# Altium schematic sheet EVB PRO.SchDoc("EVB PRO")
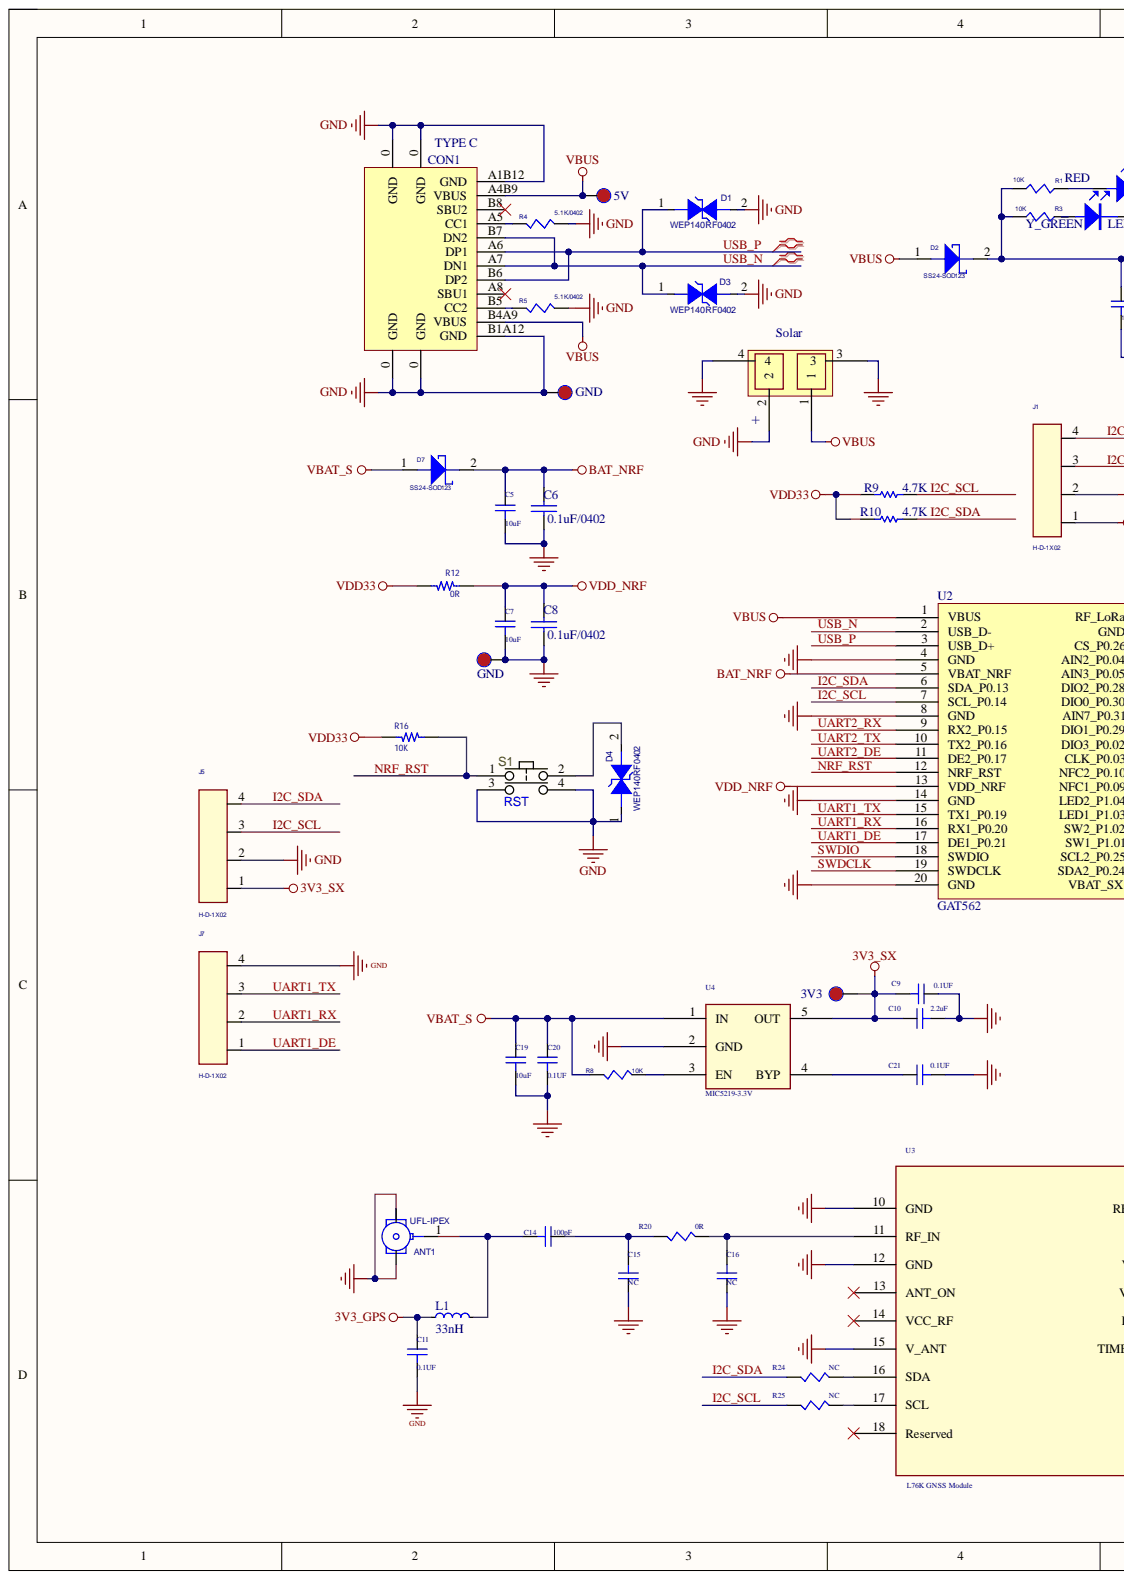
[diagram p0: EVB PRO.SchDoc, left]
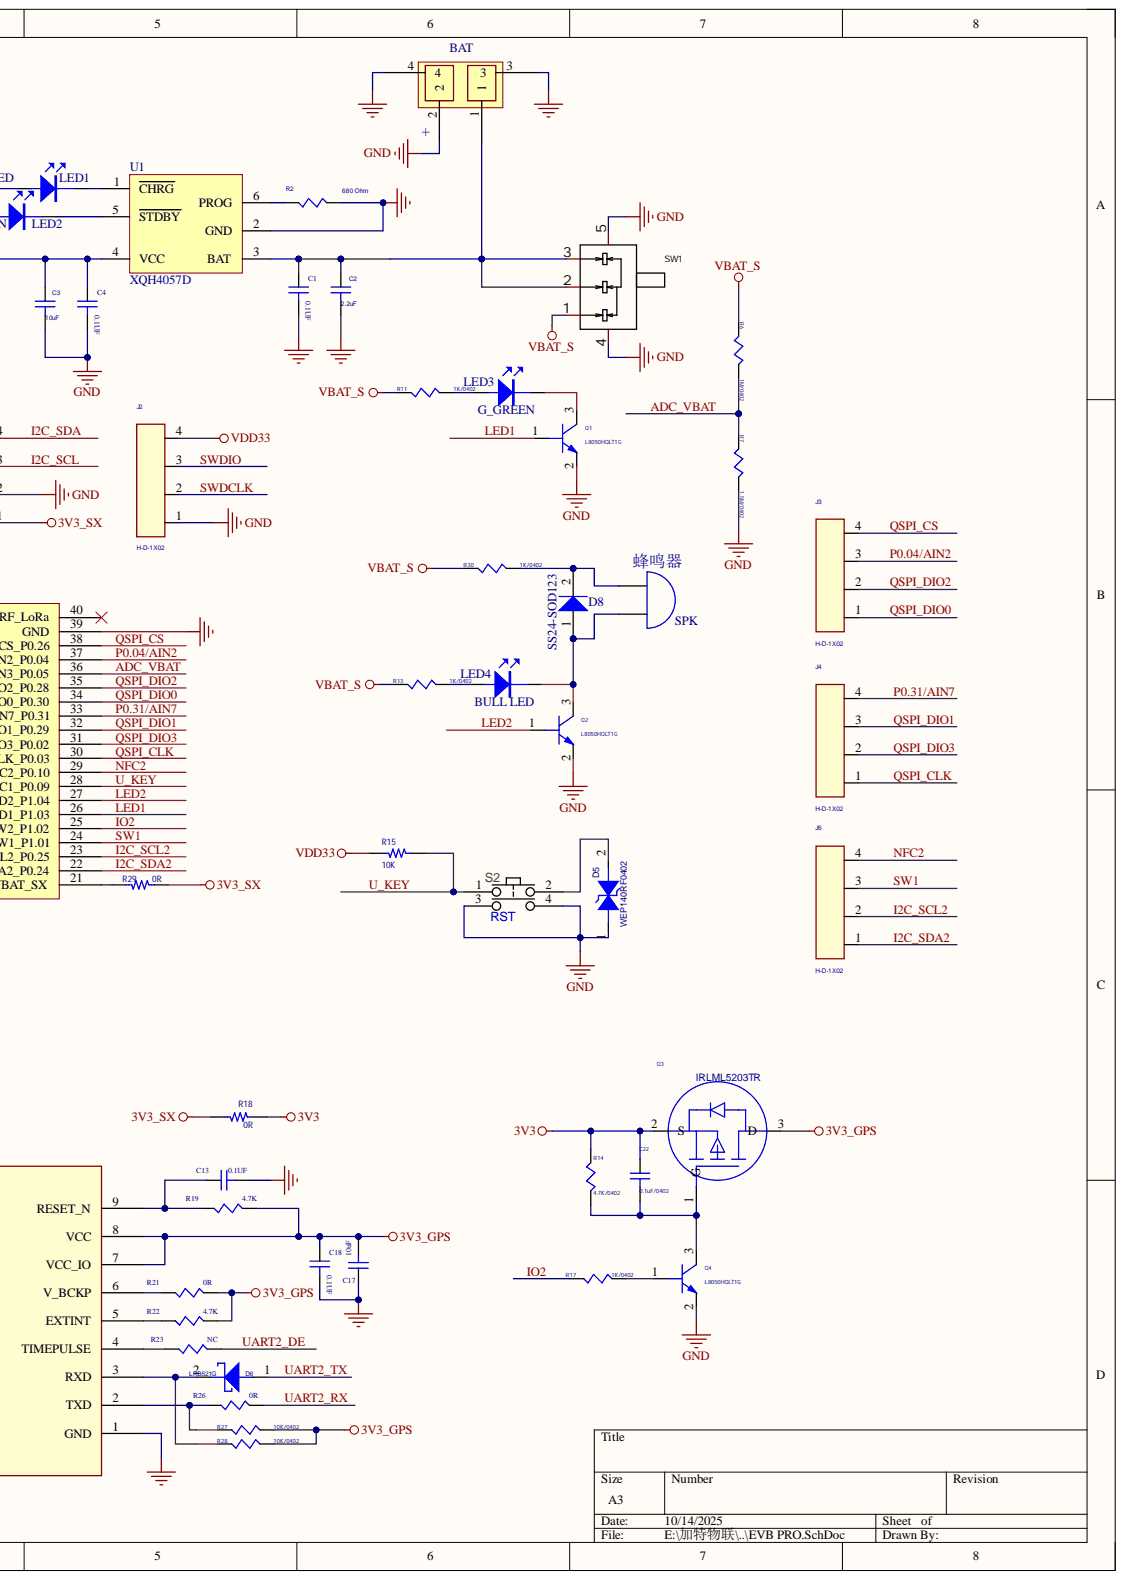
[diagram p1: EVB PRO.SchDoc, right]

## Parts
3V3  (no value)  [PAD_29mil]  (no BOM)
5V  (no value)  [PAD_29mil]  (no BOM)
ANT1  UFL-IPEX  [IPEX-0.7X2.2-1.35_3D]
BAT  H1  [125T-2P]  (value not drawn)
C1  0.1UF  [C0402]
C2  2.2uF  [C0402]
C3  10uF  [C0402]
C4  0.1UF  [C0402]
C5  10uF  [C0402]
C6  0.1uF/0402  [C0402]
C7  10uF  [C0402]
C8  0.1uF/0402  [C0402]
C9  0.1UF  [C0402]
C10  2.2uF  [C0402]
C11  0.1UF  [C0402]
C13  0.1UF  [C0402]
C14  100pF  [C0402]
C15  NC  [C0402]
C16  NC  [C0402]
C17  10uF  [C0402]
C18  0.1UF  [C0402]
C19  10uF  [C0402]
C20  0.1UF  [C0402]
C21  0.1UF  [C0402]
C22  0.1uF/0402  [C0402]
CON1  TYPE C  [TYPE C 12]
D1  WEP140RF0402  [ESD0402]
D2  SS24-SOD123  [SOD-123-1]
D3  WEP140RF0402  [ESD0402]
D4  WEP140RF0402  [ESD0402]
D5  WEP140RF0402  [ESD0402]
D6  LRB521G  [SOD723]
D7  SS24-SOD123  [SOD-123-1]
D8  SS24-SOD123  [SOD-123-1]
GND  (no value)  [PAD_29mil]  (no BOM)
GND  (no value)  [PAD_29mil]  (no BOM)
J1  H-D-1X02  [HDR1X4]
J2  H-D-1X02  [HDR1X4]
J3  H-D-1X02  [HDR1X4]
J4  H-D-1X02  [HDR1X4]
J5  H-D-1X02  [HDR1X4]
J6  H-D-1X02  [HDR1X4]
J7  H-D-1X02  [HDR1X4]
L1  33nH  [R0402]
LED1  RED  [LED0603_RED_3D]
LED2  Y_GREEN  [LED0603_RED_3D]
LED3  G_GREEN  [LED0603_RED_3D]
LED4  BULL LED  [LED0603_RED_3D]
Q1  L8050HQLT1G  [SOT23]
Q2  L8050HQLT1G  [SOT23]
Q3  IRLML5203TR  [SOT23]
Q4  L8050HQLT1G  [SOT23]
R1  10K  [R0402]
R2  680 Ohm  [R0402]
R3  10K  [R0402]
R4  5.1K/0402  [R0402]
R5  5.1K/0402  [R0402]
R6  1M/0402  [R0402]
R7  1.5M/0402  [R0402]
R8  10K  [R0402]
R9  4.7K  [X0402R_3D]
R10  4.7K  [X0402R_3D]
R11  1K/0402  [R0402]
R12  0R  [R0402]
R13  1K/0402  [R0402]
R14  4.7K/0402  [R0402]
R15  10K  [R0402]
R16  10K  [R0402]
R17  1K/0402  [R0402]
R18  0R  [R0402]
R19  4.7K  [R0402]
R20  0R  [R0402]
R21  0R  [R0402]
R22  4.7K  [R0402]
R23  NC  [R0402]
R24  NC  [R0402]
R25  NC  [R0402]
R26  0R  [R0402]
R27  10K/0402  [R0402]
R28  10K/0402  [R0402]
R29  0R  [R0402]
R30  1K/0402  [R0402]
S1  RST  [KEY_3*3*1.5]
S2  RST  [KEY_3*3*1.5]
Solar  H1  [125T-2P]  (value not drawn)
SPK  蜂鸣器  [HDR1X2]
SW1  SK12D07VG4  [SW-TH_MSK12C02]  (value not drawn)
U1  XQH4057D  [XQH4057D]
U2  GAT562  [GAT562]
U3  L76K GNSS Module  [MAX-7]
U4  MIC5219-3.3V  [SOT23-5]

## Nets
3V3: C22.1 Q3.2 R14.2 R18.2
3V3_GPS: C11.1 C17.2 C18.1 L1.2 Q3.3 R19.1 R21.1 R22.1 R27.1 R28.1 U3.7(VCC_IO) U3.8(VCC)
3V3_SX: 3V3.1 C9.2 C10.1 J1.1 J5.1 R18.1 R29.2 U4.5(OUT)
BAT_NRF: C5.1 C6.2 D7.2 U2.5(VBAT_NRF)
GND: ANT1.2 ANT1.3 BAT.2 BAT.3 BAT.4 C1.2 C2.2 C3.2 C4.2 C5.2 C6.1 C7.2 C8.1 C9.1 C10.2 C11.2 C13.2 C15.1 C16.1 C17.1 C18.2 C19.2 C20.2 C21.2 CON1.0(GND) CON1.A1B12(GND) CON1.B1A12(GND) D1.2 D3.2 D4.1 D5.1 GND.1 J1.2 J2.1 J5.2 J7.4 Q1.2 Q2.2 Q4.2 R2.2 R4.2 R5.2 R7.1 S1.3 S1.4 S2.3 S2.4 Solar.2 Solar.3 Solar.4 SW1.4 SW1.5 U1.2(GND) U2.4(GND) U2.8(GND) U2.14(GND) U2.20(GND) U2.39(GND) U3.1(GND) U3.10(GND) U3.12(GND) U3.15(V_ANT) U4.2(GND)
VBAT_S: C19.1 C20.1 D7.1 R6.2 R8.1 R11.2 R13.2 R30.2 SW1.1 U4.1(IN)
VBUS: 5V.1 CON1.A4B9(VBUS) CON1.B4A9(VBUS) D2.1 Solar.1 U2.1(VBUS)
VDD33: J2.4 R9.1 R10.2 R12.1 R15.1 R16.1
VDD_NRF: C7.1 C8.2 R12.2 U2.13(VDD_NRF)
ADC_VBAT: R6.1 R7.2 U2.36(AIN3_P0.05)
I2C_SCL: J1.3 J5.3 R9.2 R25.2 U2.7(SCL_P0.14)
I2C_SCL2: J6.2 U2.23(SCL2_P0.25)
I2C_SDA: J1.4 J5.4 R10.1 R24.2 U2.6(SDA_P0.13)
I2C_SDA2: J6.1 U2.22(SDA2_P0.24)
IO2: R17.2 U2.25(SW2_P1.02)
LED1: Q1.1 U2.26(LED1_P1.03)
LED2: Q2.1 U2.27(LED2_P1.04)
NFC2: J6.4 U2.29(NFC2_P0.10)
NRF_RST: R16.2 S1.1 U2.12(NRF_RST)
P0.04/AIN2: J3.3 U2.37(AIN2_P0.04)
P0.31/AIN7: J4.4 U2.33(AIN7_P0.31)
QSPI_CLK: J4.1 U2.30(CLK_P0.03)
QSPI_CS: J3.4 U2.38(CS_P0.26)
QSPI_DIO0: J3.1 U2.34(DIO0_P0.30)
QSPI_DIO1: J4.3 U2.32(DIO1_P0.29)
QSPI_DIO2: J3.2 U2.35(DIO2_P0.28)
QSPI_DIO3: J4.2 U2.31(DIO3_P0.02)
SW1: J6.3 U2.24(SW1_P1.01)
SWDCLK: J2.2 U2.19(SWDCLK)
SWDIO: J2.3 U2.18(SWDIO)
U_KEY: R15.2 S2.1 U2.28(NFC1_P0.09)
UART1_DE: J7.1 U2.17(DE1_P0.21)
UART1_RX: J7.2 U2.16(RX1_P0.20)
UART1_TX: J7.3 U2.15(TX1_P0.19)
UART2_DE: R23.1 U2.11(DE2_P0.17)
UART2_RX: R26.1 U2.9(RX2_P0.15)
UART2_TX: D6.1 U2.10(TX2_P0.16)
USB_N: CON1.A7(DN1) CON1.B7(DN2) D3.1 U2.2(USB_D-)
USB_P: CON1.A6(DP1) CON1.B6(DP2) D1.1 U2.3(USB_D+)
NetANT1_1: ANT1.1 C14.2 L1.1
NetBAT_1: BAT.1 C1.1 C2.1 SW1.2 SW1.3 U1.3(BAT)
NetC3_1: C3.1 C4.1 D2.2 R1.2 R3.2 U1.4(VCC)
NetC13_1: C13.1 R19.2 U3.9(RESET_N)
NetC14_1: C14.1 C15.2 R20.2
NetC16_2: C16.2 R20.1 U3.11(RF_IN)
NetC21_1: C21.1 U4.4(BYP)
NetC22_2: C22.2 Q3.1 Q4.3 R14.1
NetCON1_A5: CON1.A5(CC1) R4.1
NetCON1_B5: CON1.B5(CC2) R5.1
NetD4_2: D4.2 S1.2
NetD5_2: D5.2 S2.2
NetD6_2: D6.2 R28.2 U3.3(RXD)
NetD8_1: D8.1 LED4.2 Q2.3 SPK.2
NetD8_2: D8.2 R30.1 SPK.1
NetLED1_1: LED1.1 R1.1
NetLED1_2: LED1.2 U1.1(CHRG)
NetLED2_1: LED2.1 R3.1
NetLED2_2: LED2.2 U1.5(STDBY)
NetLED3_1: LED3.1 R11.1
NetLED3_2: LED3.2 Q1.3
NetLED4_1: LED4.1 R13.1
NetQ4_1: Q4.1 R17.1
NetR2_1: R2.1 U1.6(PROG)
NetR8_2: R8.2 U4.3(EN)
NetR21_2: R21.2 U3.6(V_BCKP)
NetR22_2: R22.2 U3.5(EXTINT)
NetR23_2: R23.2 U3.4(TIMEPULSE)
NetR24_1: R24.1 U3.16(SDA)
NetR25_1: R25.1 U3.17(SCL)
NetR26_2: R26.2 R27.2 U3.2(TXD)
NetR29_1: R29.1 U2.21(VBAT_SX)

Not connected: CON1 A8(SBU1) B8(SBU2); U2 40(RF_LoRa); U3 13(ANT_ON) 14(VCC_RF) 18(Reserved)

## Library data of the parts
3V3 5V GND GND = TEST-DOT: Library Name: EasyARM-i.MX283-EV.SCHLIB
ANT1 = UFL-IPEX_3PIN-S: Component Kind: Standard; Library Name: schlib.SCHLIB
BAT Solar = 2P BAT: Component Kind: Standard; Library Name: PCB_Project.SCHLIB
C1 C4 C5 C7 C9-C11 C13-C21 = 0_1.1UF: Component Kind: Standard
C2 C3 C22 = 0.1UF: Component Kind: Standard
C6 C8 = CAP: Capacitor; Component Kind: Standard; Library Name: 电阻容感类.SchLib
CON1 = TYPE C: MODE:U262-161N-4BVC11/C319148; Component Kind: Standard; Library Name: Schlib1.SchLib
D1 D3-D5 = DIODE SCHOTTKY_1: Component Kind: Standard
D2 D6 D7 = DIODE SCHOTTKY_0: DIODE 1N5817,DIP, 20V, 1A; Vendor: DIODES Incorporated.; Vendor PN: SBR3A40SA; Component Kind: Standard; Package: SMA
D8 = Diode: Default Diode; Code_JEDEC: DO-214-AB; Component Kind: Standard; LatestRevisionDate: 17-Jul-2002; LatestRevisionNote: Re-released for DXP Platform.; Library Name: STM32_MIC29302_1V0.SCHLIB; PackageReference: SMC; PackageVersion: Sep-1996; Published: 8-Jun-2000; Publisher: Altium Limited
J1-J7 = CON6_1_Dup1: Component Kind: Standard
L1 = L0402-15n: Inductor, 320mA, ?%; 2nd source part number: 658-1328; Manufacturer: Taiyo Yuden; Manufacturer Part Number: HK100515NJ-T; Artikkelnr Noca: 33029323; Artikkelnr Simpro: N.A.; Database Table Name: Inductors$; Note: High frequency chip inductor ?0%; 2nd source Supplier: Farnell; Component Kind: Standard; Datasheet: http://www.yuden.co.jp/productdata/catalog/en/mlci04_e.pdf; Footprint Doc: 0402; LatestRevisionDate: 2012-09-19; Library Name: Nordic Passive DB.DbLib; Part Number: L0402-15n; Pin Count: 2; Published: 2010-07-12; Supplier: Digi-Key; Supplier part number: 587-1521-1-ND; Value: 33nH; Publisher: Nordic Semiconductor
LED1-LED4 = LED: Component Kind: Standard; Library Name: LoRa DTU_20180911.SCHLIB
Q1 Q2 Q4 = 1R1001_0: Component Kind: Standard
Q3 = FETP-GSD_0: PARTS: 1; %195%%232%%202%%246%: MOSFET-P沟道--30V--3A-98MOHM--20V-SOT23; %208%%161%%192%%224%%195%%251%%179%%198%: MOSFET; CODE: 15060138; COMPONENT_HIGH_MAX: 1.12MM; LEVEL: STD; OriginalSymbolOrigin: (0,0); Component Kind: Standard; PKG_TYPE: SOT23
R1-R8 R11 R13 R14 R17 R19-R28 R30 = R0402_1.06K_WALSIN: NO_STUFF: .; VENDER\PN: WALSIN\WR04X8061FT; Component Kind: Standard
R9 R10 = Res1: Resistor; Component Kind: Standard; LatestRevisionDate: 17-Jul-2002; LatestRevisionNote: Re-released for DXP Platform.; Library Name: Miscellaneous Devices.IntLib; PackageReference: AXIAL-0.3; Published: 8-Jun-2000; Signal Integrity: Res; Simulation: RESISTOR; Value: 4.7K; Publisher: Altium Limited
R12 R15 R16 R18 R29 = RES: Resistor , 2K Ohm 0402  5%; Component Kind: Standard; Library Name: AcSiP 2013.SchLib
S1 S2 = KEY1: 3*3*1.5中龟按键; Component Kind: Standard
SPK = BELL: SMD 9032; Component Kind: Standard; Library Name: 实验板原理图.SCHLIB
SW1 = SK12D07VG4: 编号: C393937,关高度: 8.8mm; Manufacturer: G-Switch(品赞); Manufacturer Part: MK-12C03-G015; Actuator Color: 白色; Actuator Style: 矩形柱状; Add into BOM: yes; Circuit: 单刀双掷; Current Rating (DC): 1A; Mechanical Life: 10000; Pin Style: SMD接片; Switch Height: 4.25mm; Switch Length: 6.65mm; Switch Width: 2.75mm; Voltage Rating (DC): 6V; Component Kind: Standard; Convert to PCB: yes; Datasheet: https://atta.szlcsc.com/upload/public/pdf/source/20210903/D741BE8374CE854DD630D54FFF9BD91E.pdf; Device: SK12D07VG4; JLCPCB Part Class: Extended Part; LCSC Part Name: 3脚拨动开关 P=2mm; Library Name: PCB_Project.SCHLIB; Mounting Style: 卧贴; Name: MK-12C03-G015; Operating Temperature: -20℃~+70℃; PCB3D: SW-SMD_MK-12C03-G015; Supplier: LCSC; Supplier Footprint: SMD; Supplier Part: C393937; Symbol: SK12D07VG4
U3 = MAX-7: Component Kind: Standard
U4 = REG CAT7101_2: Component Kind: Standard
U1 = XQH4057D: Component Kind: Standard; Library Name: DRT.SchLib
U2 = GAT562: Component Kind: Standard; Library Name: ALL Schlib.SchLib
